# Altium schematic sheet Zynq DDR Interface & Termination.SchDoc
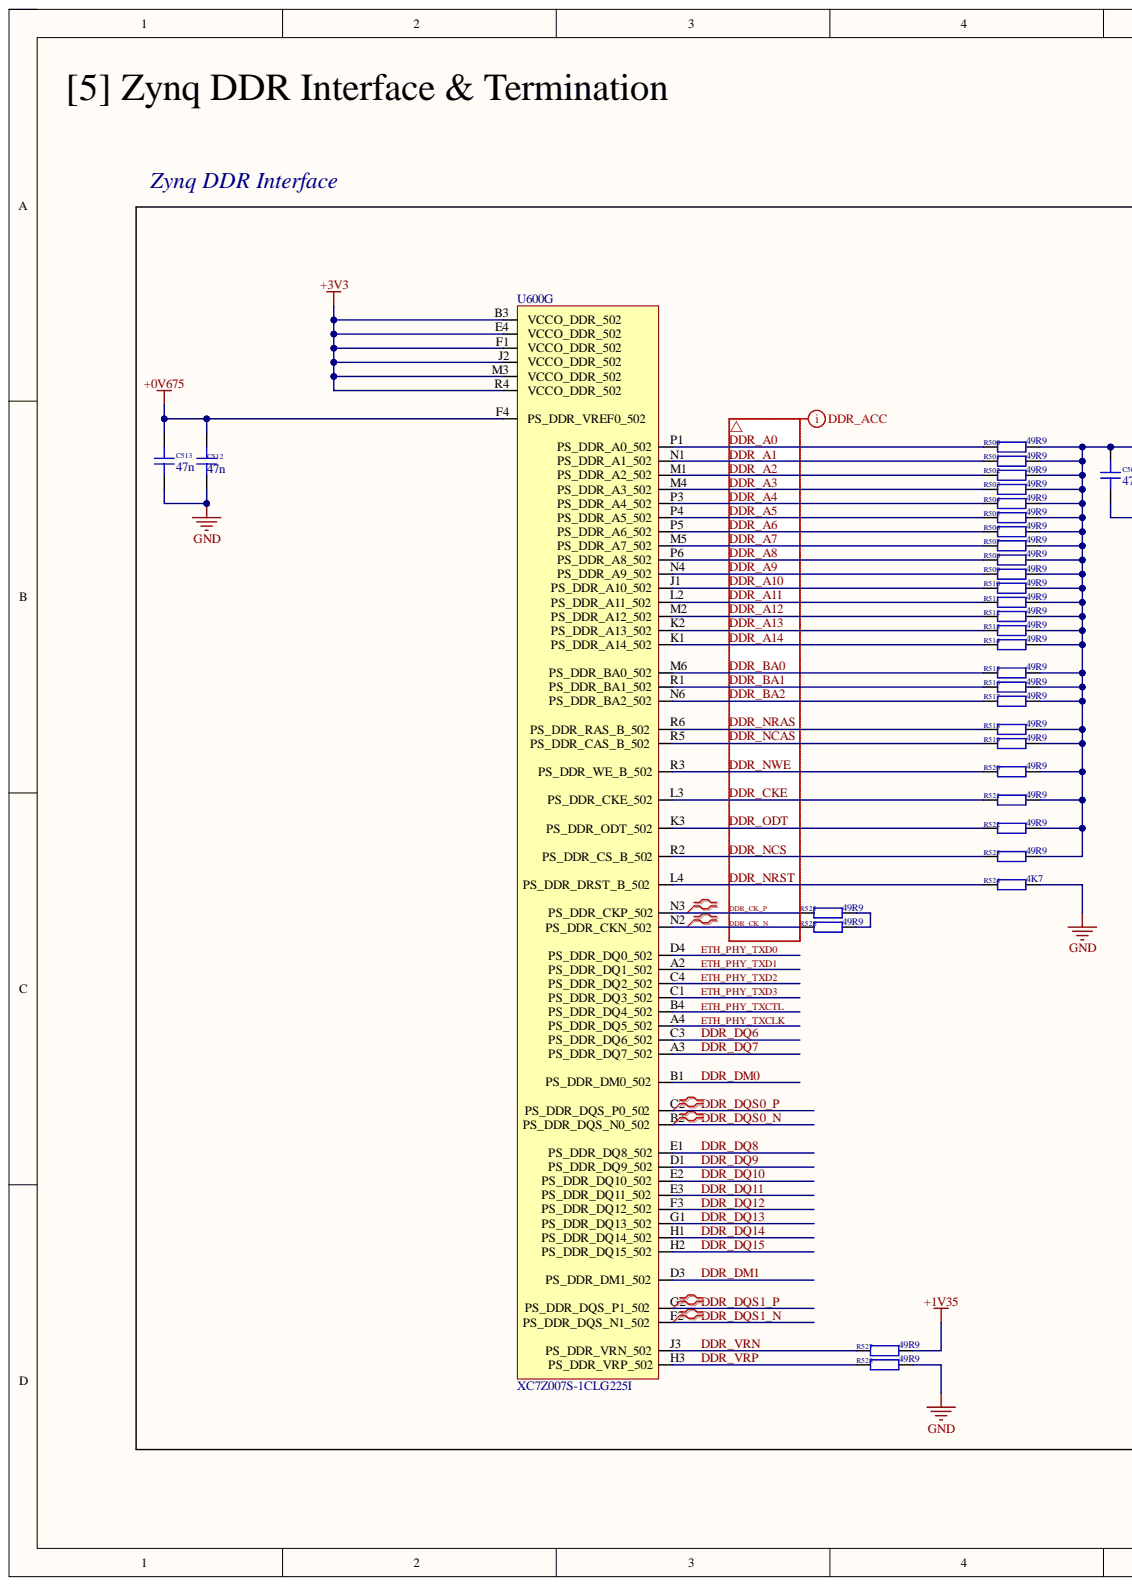
[diagram p0: Zynq DDR Interface & Termination.SchDoc, left]
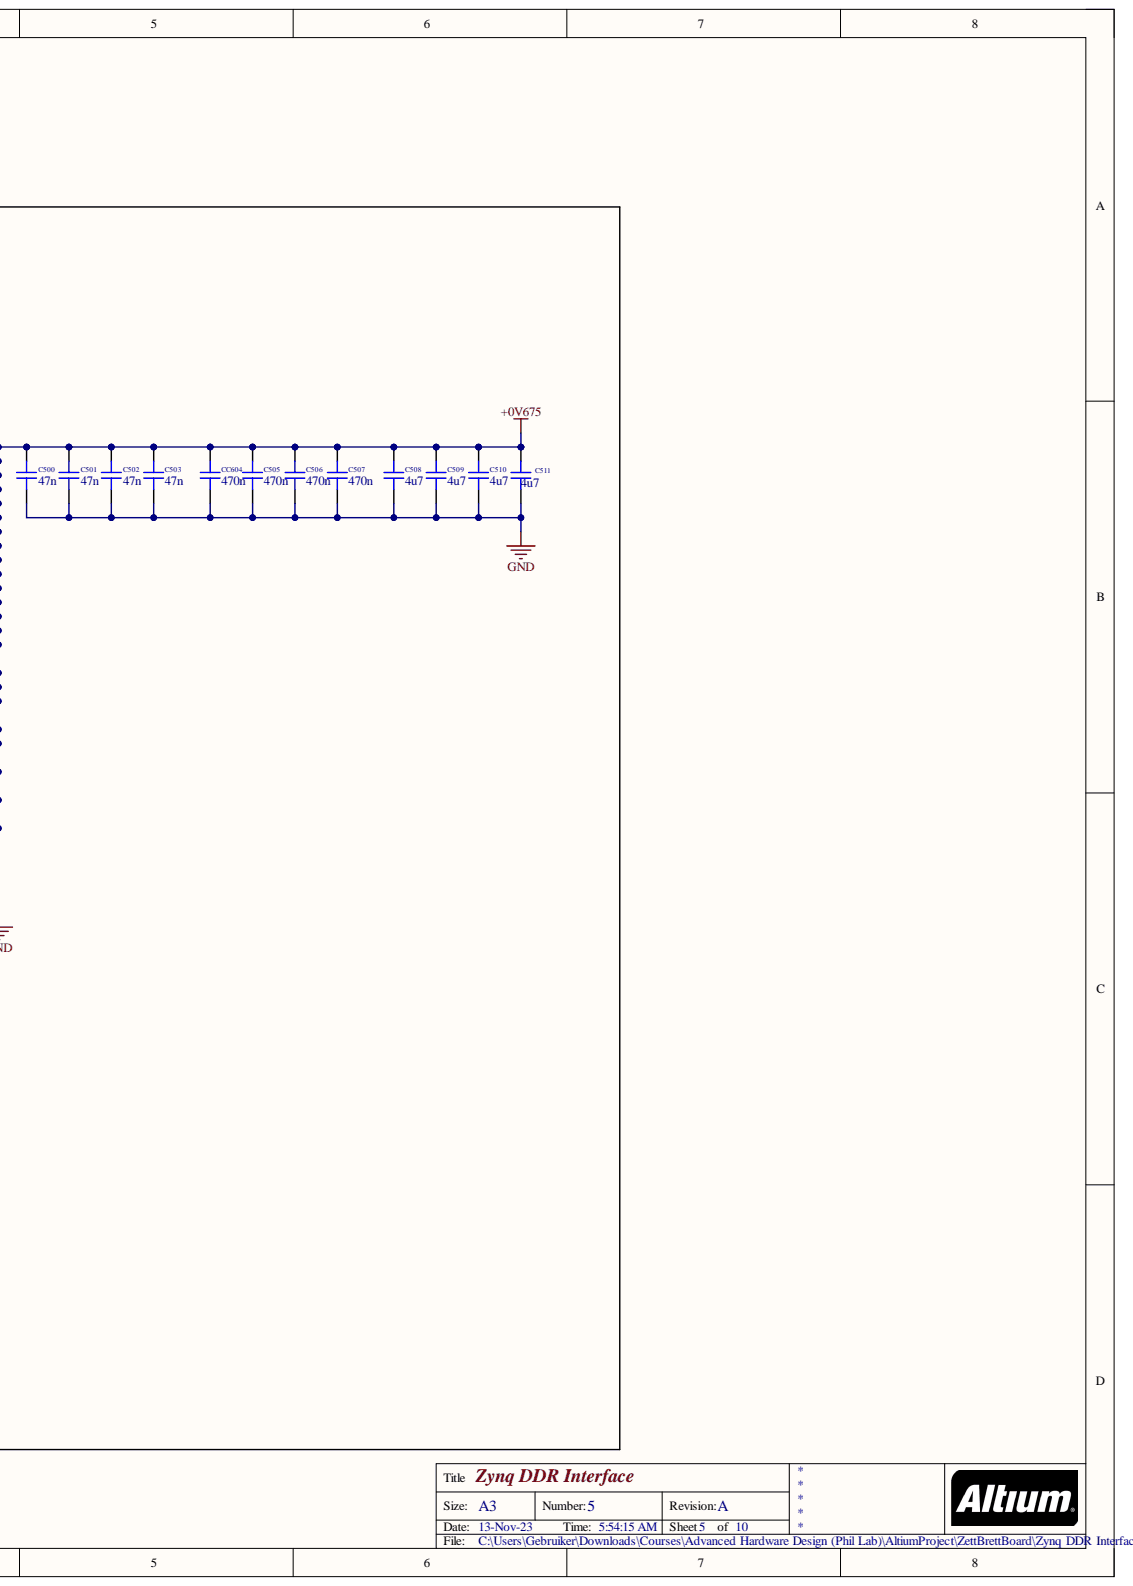
[diagram p1: Zynq DDR Interface & Termination.SchDoc, right]

## Parts
C500  47n  [CAP_1210]
C501  47n  [CAP_1210]
C502  47n  [CAP_1210]
C503  47n  [CAP_1210]
C505  470n  [CAP_1210]
C506  470n  [CAP_1210]
C507  470n  [CAP_1210]
C508  4u7  [CAP_1210]
C509  4u7  [CAP_1210]
C510  4u7  [CAP_1210]
C511  4u7  [CAP_1210]
C512  47n  [CAP_1210]
C513  47n  [CAP_1210]
CC604  470n  [CAP_1210]
R500  49R9  [RES_0402]
R501  49R9  [RES_0402]
R502  49R9  [RES_0402]
R503  49R9  [RES_0402]
R504  49R9  [RES_0402]
R505  49R9  [RES_0402]
R506  49R9  [RES_0402]
R507  49R9  [RES_0402]
R508  49R9  [RES_0402]
R509  49R9  [RES_0402]
R510  49R9  [RES_0402]
R511  49R9  [RES_0402]
R512  49R9  [RES_0402]
R513  49R9  [RES_0402]
R514  49R9  [RES_0402]
R515  49R9  [RES_0402]
R516  49R9  [RES_0402]
R517  49R9  [RES_0402]
R518  49R9  [RES_0402]
R519  49R9  [RES_0402]
R520  49R9  [RES_0402]
R521  49R9  [RES_0402]
R522  49R9  [RES_0402]
R523  49R9  [RES_0402]
R524  4K7  [RES_0402]
R525  49R9  [RES_0402]
R526  49R9  [RES_0402]
R527  49R9  [RES_0402]
R528  49R9  [RES_0402]
U600  XC7Z007S-1CLG225I  [CLG225]  (part G)

## Nets
+0V675: C500.2 C501.2 C502.2 C503.2 C505.2 C506.2 C507.2 C508.2 C509.2 C510.2 C511.2 C512.2 C513.2 CC604.2 R500.2 R501.2 R502.2 R503.2 R504.2 R505.2 R506.2 R507.2 R508.2 R509.2 R510.2 R511.2 R512.2 R513.2 R514.2 R515.2 R516.2 R517.2 R518.2 R519.2 R520.2 R521.2 R522.2 R523.2 U600.F4
+1V35: R527.2
+3V3: U600.B3 U600.E4 U600.F1 U600.J2 U600.M3 U600.R4
GND: C500.1 C501.1 C502.1 C503.1 C505.1 C506.1 C507.1 C508.1 C509.1 C510.1 C511.1 C512.1 C513.1 CC604.1 R524.2 R528.2
DDR_A0: R500.1 U600.P1
DDR_A1: R501.1 U600.N1
DDR_A2: R502.1 U600.M1
DDR_A3: R503.1 U600.M4
DDR_A4: R504.1 U600.P3
DDR_A5: R505.1 U600.P4
DDR_A6: R506.1 U600.P5
DDR_A7: R507.1 U600.M5
DDR_A8: R508.1 U600.P6
DDR_A9: R509.1 U600.N4
DDR_A10: R510.1 U600.J1
DDR_A11: R511.1 U600.L2
DDR_A12: R512.1 U600.M2
DDR_A13: R513.1 U600.K2
DDR_A14: R514.1 U600.K1
DDR_BA0: R515.1 U600.M6
DDR_BA1: R516.1 U600.R1
DDR_BA2: R517.1 U600.N6
DDR_CK_N: R526.1 U600.N2
DDR_CK_P: R525.1 U600.N3
DDR_CKE: R521.1 U600.L3
DDR_DM0: U600.B1
DDR_DM1: U600.D3
DDR_DQ6: U600.C3
DDR_DQ7: U600.A3
DDR_DQ8: U600.E1
DDR_DQ9: U600.D1
DDR_DQ10: U600.E2
DDR_DQ11: U600.E3
DDR_DQ12: U600.F3
DDR_DQ13: U600.G1
DDR_DQ14: U600.H1
DDR_DQ15: U600.H2
DDR_DQS0_N: U600.B2
DDR_DQS0_P: U600.C2
DDR_DQS1_N: U600.F2
DDR_DQS1_P: U600.G2
DDR_NCAS: R519.1 U600.R5
DDR_NCS: R523.1 U600.R2
DDR_NRAS: R518.1 U600.R6
DDR_NRST: R524.1 U600.L4
DDR_NWE: R520.1 U600.R3
DDR_ODT: R522.1 U600.K3
DDR_VRN: R527.1 U600.J3
DDR_VRP: R528.1 U600.H3
ETH_PHY_TXCLK: U600.A4
ETH_PHY_TXCTL: U600.B4
ETH_PHY_TXD0: U600.D4
ETH_PHY_TXD1: U600.A2
ETH_PHY_TXD2: U600.C4
ETH_PHY_TXD3: U600.C1
NetR525_2: R525.2 R526.2

## Library data of the parts
C500-C503 C505-C513 CC604 = CAP_1210: Capacitor SMD 1210; Component Kind: Standard; Library Name: Schematic-Lib-PhilsLab.SchLib
R500-R528 = RES_0402: Resistor SMD 0402; Component Kind: Standard; Library Name: Schematic-Lib-PhilsLab.SchLib
U600 = XC7Z007S-1CLG225I: Xilinx FPGA SoC Zynq 7-Series XC7Z007S CLG255 Package Industrial Temperature Grade; Component Kind: Standard; Manufacturer: Xilinx; Manufacturer Part Number: XC7Z007S-1CLG225I; Library Name: Schematic-Lib-PhilsLab.SchLib
